# Altium schematic sheet 01.Main.SchDoc
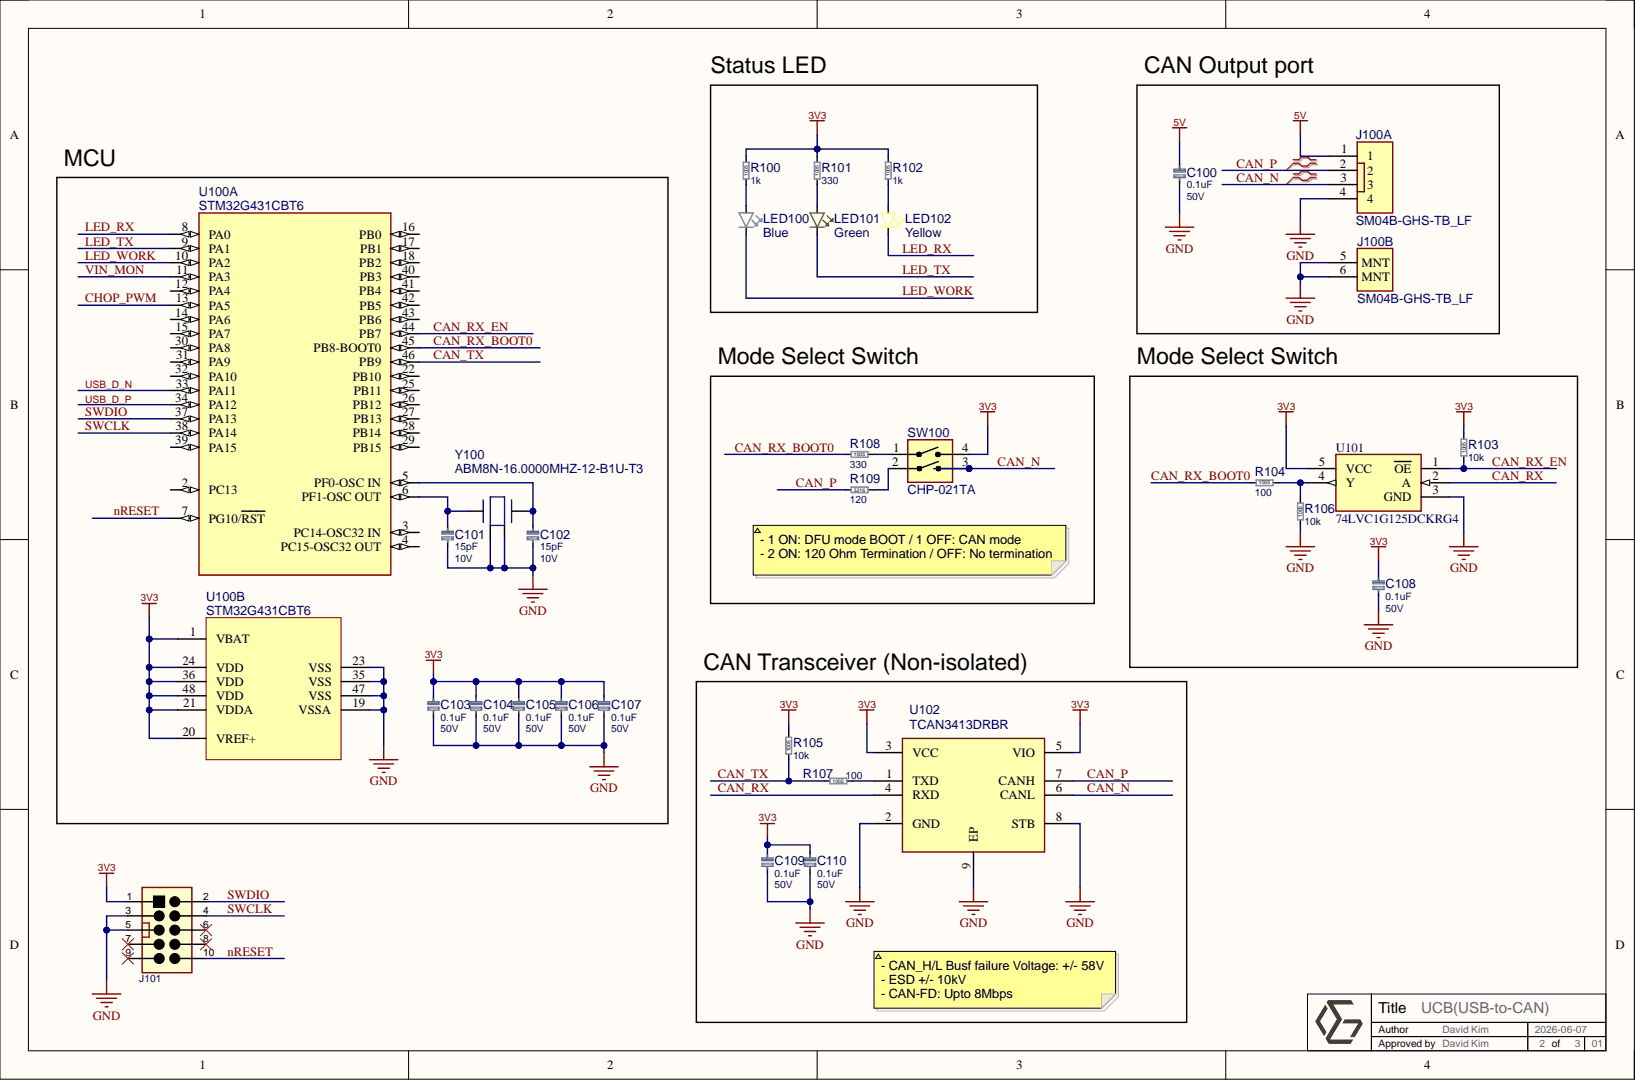
[diagram p0: 01.Main.SchDoc]

## Parts
C100  0.1uF  Voltage Rating: 50V  [C-1005]
C101  15pF  Voltage Rating: 10V  [C-1005]
C102  15pF  Voltage Rating: 10V  [C-1005]
C103  0.1uF  Voltage Rating: 50V  [C-1005]
C104  0.1uF  Voltage Rating: 50V  [C-1005]
C105  0.1uF  Voltage Rating: 50V  [C-1005]
C106  0.1uF  Voltage Rating: 50V  [C-1005]
C107  0.1uF  Voltage Rating: 50V  [C-1005]
C108  0.1uF  Voltage Rating: 50V  [C-1005]
C109  0.1uF  Voltage Rating: 50V  [C-1005]
C110  0.1uF  Voltage Rating: 50V  [C-1005]
J100  SM04B-GHS-TB_LF  [SM04B-GHS-TB]  (parts A,B)
J101  FTSH-105-01-F-DV-K-TR  [J-Samtec-FTSH-105-01-F-DV-K-TR]  (value not drawn)
LED100  Blue  [LED-1608-BLUE]
LED101  Green  [LED-1608-GREEN]
LED102  Yellow  [LED-1608-YELLOW]
R100  1k  [R-1005]
R101  330  [R-1005]
R102  1k  [R-1005]
R103  10k  [R-1005]
R104  100  [R-1005]
R105  10k  [R-1005]
R106  10k  [R-1005]
R107  100  [R-1005]
R108  330  [R-1005]
R109  120  [R-3216]
SW100  CHP-021TA  [CHP021TA]
U100  STM32G431CBT6  [U-ST-LQFP48]  (parts A,B)
U101  74LVC1G125DCKRG4  [SOT65P210X110-5N]
U102  TCAN3413DRBR  [SON65P300X300X100-9N-D]
Y100  ABM8N-16.0000MHZ-12-B1U-T3  [NX3225GA25000MSTDCRG2]

## Nets
3V3: C103.1 C104.1 C105.1 C106.1 C107.1 C108.1 C109.1 C110.1 J101.1 R100.2 R101.2 R102.2 R103.2 R105.2 SW100.4 U100.1(VBAT) U100.20(VREF+) U100.21(VDDA) U100.24(VDD) U100.36(VDD) U100.48(VDD) U101.5(VCC) U102.3(VCC) U102.5(VIO)
5V: C100.1 J100.1
GND: C100.2 C101.2 C102.2 C103.2 C104.2 C105.2 C106.2 C107.2 C108.2 C109.2 C110.2 J100.4 J100.5(MNT) J100.6(MNT) J101.3 J101.5 R106.1 U100.19(VSSA) U100.23(VSS) U100.35(VSS) U100.47(VSS) U101.3(GND) U102.2(GND) U102.8(STB) U102.9(EP) Y100.2 Y100.4
CAN_N: J100.3 SW100.3 U102.6(CANL)
CAN_P: J100.2 R109.1 U102.7(CANH)
CAN_RX: U101.2(A) U102.4(RXD)
CAN_RX_BOOT0: R104.1 R108.1 U100.45(PB8-BOOT0)
CAN_RX_EN: R103.1 U100.44(PB7) U101.1(OE)
CAN_TX: R105.1 R107.1 U100.46(PB9)
CHOP_PWM: U100.13(PA5)
LED_RX: LED102.K U100.8(PA0)
LED_TX: LED101.K U100.9(PA1)
LED_WORK: LED100.K U100.10(PA2)
nRESET: J101.10 U100.7(PG10/RST)
SWCLK: J101.4 U100.38(PA14)
SWDIO: J101.2 U100.37(PA13)
USB_D_N: U100.33(PA11)
USB_D_P: U100.34(PA12)
VIN_MON: U100.11(PA3)
NetC101_1: C101.1 U100.6(PF1-OSC_OUT) Y100.1
NetC102_1: C102.1 U100.5(PF0-OSC_IN) Y100.3
NetLED100_A: LED100.A R100.1
NetLED101_A: LED101.A R101.1
NetLED102_A: LED102.A R102.1
NetR104_2: R104.2 R106.2 U101.4(Y)
NetR107_2: R107.2 U102.1(TXD)
NetR108_2: R108.2 SW100.1
NetR109_2: R109.2 SW100.2

Not connected: J101 6 7 8 9; U100 2(PC13) 3(PC14-OSC32_IN) 4(PC15-OSC32_OUT) 12(PA4) 14(PA6) 15(PA7) 16(PB0) 17(PB1) 18(PB2) 22(PB10) 25(PB11) 26(PB12) 27(PB13) 28(PB14) 29(PB15) 30(PA8) 31(PA9) 32(PA10) 39(PA15) 40(PB3) 41(PB4) 42(PB5) 43(PB6)

## Library data of the parts
C100-C110 = C-CAP1005: 1005 Size Ceramic MLCC CAP; Tolerance: 10%; Component Kind: Standard; Library Name: UCB_Rev_01.SchLib
  C100 C103-C110: Value: 0.1uF
  C101 C102: Value: 15pF
J100 = J-JST-SM04B-GHS-TB_LF: JST 1.25mm pitch GH series connector. Side mount type; Manufacturer_Name: JST (JAPAN SOLDERLESS TERMINALS); Manufacturer_Part_Number: SM04B-GHS-TB(LF)(SN); Arrow Part Number: SM04B-GHS-TB(LF)(SN); Arrow Price/Stock: https://www.arrow.com/en/products/sm04b-ghs-tb-lf-sn-/jst-manufacturing?region=europe; Component Kind: Standard; Datasheet Link: http://uk.rs-online.com/web/p/products/7521797; Mouser Part Number: N/A; Mouser Price/Stock: https://www.mouser.co.uk/ProductDetail/JST-Commercial/SM04B-GHS-TBLFSN?qs=QpmGXVUTftEJzKB1YHGCXw%3D%3D; Library Name: UCB_Rev_01.SchLib
J101 = J-Samtec-FTSH-105-01-F-DV-K-TR: CONN HEADER SMD 10POS 1.27MM; Max Current Rating: 1.75A; Number of Contacts: 10; Component Kind: Standard; Contact Material: Bronze; Contact Plating: Gold; Depth: 3.43mm; Housing Color: Black; Housing Material: Polymer; Lead Free: Lead Free; Length: 6.35mm; Max Operating Temperature: 125°C; Min Operating Temperature: -55°C; Number of Rows: 2; Orientation: Straight; Packaging: Tape and Reel; Pitch: 1.27mm; Radiation Hardening: No; Termination: Solder; Library Name: UCB_Rev_01.SchLib
LED100 = LED-Blue: 1608 CHIP LED BLUE; Manufacturer Name: Kingbright; Manufacturer Part No.: APT1608QBC/D; Component Kind: Standard; Library Name: UCB_Rev_01.SchLib
LED101 = LED-Green: 1608 CHIP LED GREEN; Manufacturer Name: Kingbright; Manufacturer Part No.: APT1608SGC; Component Kind: Standard; Library Name: UCB_Rev_01.SchLib
LED102 = LED-Yellow: 1608 CHIP LED YELLOW; Manufacturer Name: Kingbright; Manufacturer Part No.: APT1608SYCK; Component Kind: Standard; Library Name: UCB_Rev_01.SchLib
R100-R108 = R-RES1005: 1005 Size Chip FIlm Resistor; Power Rating: W; Tolerance: %; Voltage Rating: V; Component Kind: Standard; Library Name: UCB_Rev_01.SchLib
  R100 R102: Value: 1k
  R101 R108: Value: 330
  R103 R105 R106: Value: 10k
  R104 R107: Value: 100
R109 = R-RES3216: 3216 Size Chip FIlm Resistor; Power Rating: 1/4W; Tolerance: 1%; Voltage Rating: 50V; Component Kind: Standard; Value: 120; Library Name: UCB_Rev_01.SchLib
SW100 = SW-CHP-021TA: NIDEC COPAL ELECTRONICS - CHP-021TA - DIP / SIP Switch  2Pos; Arrow Part Number: CHP-021TA; Arrow Price/Stock: https://www.arrow.com/en/products/chp-021ta/nidec-copal-electronics?region=nac; Component Kind: Standard; ComponentLink1Description: Datasheet; ComponentLink1URL: http://www.farnell.com/datasheets/2604285.pdf; Datasheet Link: http://www.farnell.com/datasheets/2604285.pdf; Height: 4.9mm; Manufacturer_Name: Nidec Copal; Manufacturer_Part_Number: CHP-021TA; Mouser Part Number: 229-CHP-021TA; Mouser Price/Stock: https://www.mouser.co.uk/ProductDetail/Nidec-Components/CHP-021TA?qs=XeJtXLiO41T8q68nv%252BfGcg%3D%3D; Library Name: UCB_Rev_01.SchLib
U100 = U-ST-STM32G431CBT6: MCU 32-bit ARM Cortex M4 RISC 128KB Flash 48-Pin LQFP; Manufacturer_Name: STMicroelectronics; Manufacturer_Part_Number: STM32G431CBT3; Arrow Part Number: STM32G431CBT3; Arrow Price/Stock: https://www.arrow.com/en/products/stm32g431cbt3/stmicroelectronics?utm_currency=USD&region=nac; Component Kind: Standard; Datasheet Link: https://www.st.com/resource/en/datasheet/stm32g431c6.pdf; Height: 1.6mm; Mouser Part Number: 511-STM32G431CBT3; Mouser Price/Stock: https://www.mouser.co.uk/ProductDetail/STMicroelectronics/STM32G431CBT3?qs=BJlw7L4Cy781reBe9wrzFQ%3D%3D; Library Name: UCB_Rev_01.SchLib
U101 = U-TI-74LVC1G125DCKRG4: Buffer/Line Driver 1-CH Non-Inverting 3-ST CMOS Medical 5-Pin SC-70 T/R; Manufacturer_Name: Texas Instruments; Arrow Part Number: 74LVC1G125DCKRG4; Arrow Price/Stock: https://www.arrow.com/en/products/74lvc1g125dckrg4/texas-instruments?utm_currency=USD&region=nac; Component Kind: Standard; ComponentLink1Description: New link; ComponentLink1URL: https://www.arrow.com/en/products/74lvc1g125dckrg4/texas-instruments; Datasheet Link: https://www.arrow.com/en/products/74lvc1g125dckrg4/texas-instruments; Height: 1.1mm; Manufacturer_Part_Number: 74LVC1G125DCKRG4; Mouser Part Number: 595-74LVC1G125DCKRG4; Mouser Price/Stock: https://www.mouser.co.uk/ProductDetail/Texas-Instruments/74LVC1G125DCKRG4?qs=pajgIaoyDUgkXD%252BVPa7nSw%3D%3D; Library Name: UCB_Rev_01.SchLib
U102 = U-TI-TCAN3413DRBR: CAN Interface IC 3.3-V CAN FD transceiver with flexible IO and standby mode; Manufacturer_Name: Texas Instruments; Arrow Part Number: TCAN3413DRBR; Arrow Price/Stock: https://www.arrow.com/en/products/tcan3413drbr/texas-instruments?utm_currency=USD&region=nac; Component Kind: Standard; ComponentLink1Description: datasheet; ComponentLink1URL: https://www.ti.com/lit/ds/symlink/tcan3413.pdf?ts=1718592096977&ref_url=https%253A%252F%252Fwww.ti.com%252Fproduct%252FTCAN3413%253Futm_source%253Dgoogle%2526utm_medium%253Dcpc%2526utm_campaign%253Dasc-int-null-44700045336317218_prodfolderdynamic-cpc-pf-g; Datasheet Link: https://www.ti.com/lit/ds/symlink/tcan3413.pdf?ts=1718592096977&ref_url=https%253A%252F%252Fwww.ti.com%252Fproduct%252FTCAN3413%253Futm_source%253Dgoogle%2526utm_medium%253Dcpc%2526utm_campaign%253Dasc-int-null-44700045336317218_prodfolderdynamic-cpc-pf-g; Height: 1mm; Manufacturer_Part_Number: TCAN3413DRBR; Mouser Part Number: 595-TCAN3413DRBR; Mouser Price/Stock: https://www.mouser.co.uk/ProductDetail/Texas-Instruments/TCAN3413DRBR?qs=2wMNvWM5ZX64aRv6u22jVg%3D%3D; Library Name: UCB_Rev_01.SchLib
Y100 = ABM8N-16.0000MHZ-12-B1U-T3: 16MHz Crystal or Oscillator; Manufacturer_Name: ABRACON; Manufacturer_Part_Number: NX3225GA-25.000M-STD-CRG-2; Component Kind: Standard; ComponentLink1Description: Datasheet; ComponentLink1URL: https://www.mouser.com/datasheet/3/184/1/ABM8N.pdf; Datasheet Link: https://www.mouser.com/datasheet/3/184/1/ABM8N.pdf; Height: 0.7mm; Mouser Part Number: 815-M8N16000012B1UT3; Mouser Price/Stock: https://www.mouser.co.uk/ProductDetail/NDK/NX3225GA-25.000M-STD-CRG-2?qs=55YtniHzbhAvjNTEehpb9w%3D%3D; Library Name: UCB_Rev_01.SchLib
